# Altium schematic sheet STM Custom Shield for UAV.SchDoc("STM Custom Shield for UAV")
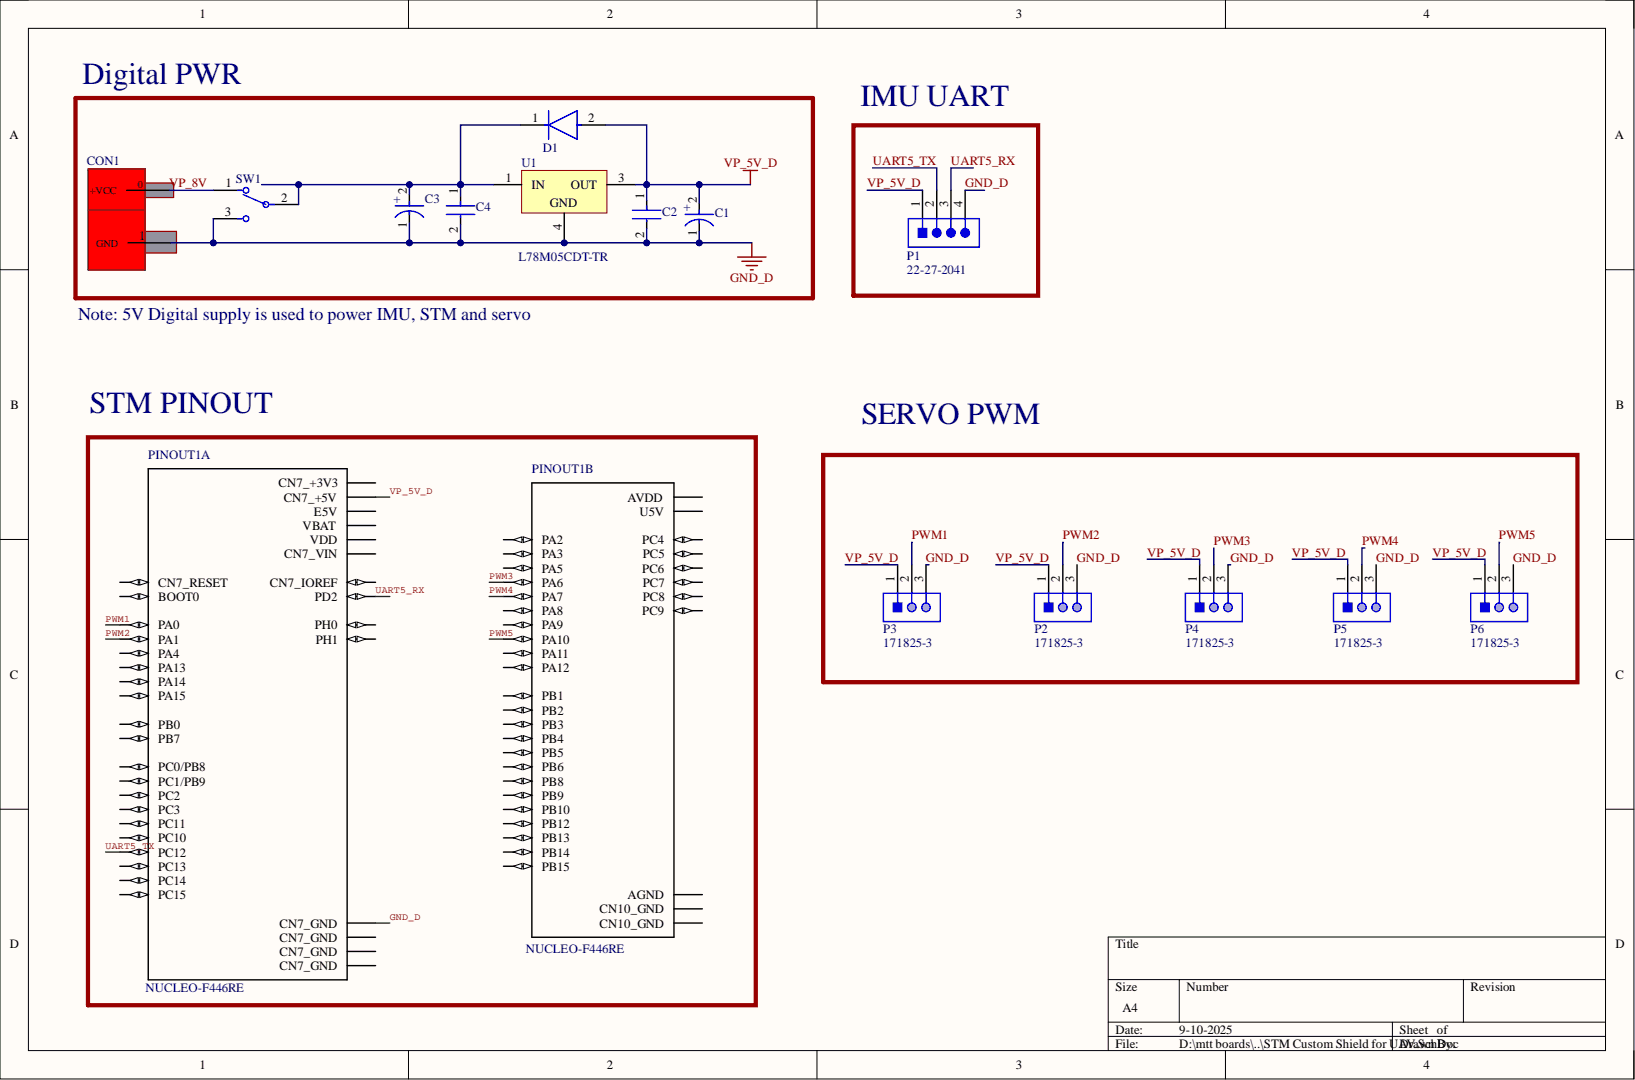
[diagram p0: STM Custom Shield for UAV.SchDoc]

## Parts
C1  EEUFR1H101  [FP-RAD-TH-D_8_0_5-L_11_5-MFG]  (value not drawn)
C2  12061C104JAT2A  [FP-1206-L_3_2_0_2-W_1_6_0-IPC_C]  (value not drawn)
C3  EEUFR1H101  [FP-RAD-TH-D_8_0_5-L_11_5-MFG]  (value not drawn)
C4  12061C104JAT2A  [FP-1206-L_3_2_0_2-W_1_6_0-IPC_C]  (value not drawn)
CON1  T CONN  [Edge Connector]  (value not drawn)
D1  STTH1L06U  [FP-SMB-MFG]  (value not drawn)
P1  22-27-2041  [MOLX-22-27-2041_V]
P2  171825-3  [TECO-171825-3_V]
P3  171825-3  [TECO-171825-3_V]
P4  171825-3  [TECO-171825-3_V]
P5  171825-3  [TECO-171825-3_V]
P6  171825-3  [TECO-171825-3_V]
PINOUT1  NUCLEO-F446RE  [*MODULE_NUCLEO-F446RE_NUCLEO-F446RE(Primary)]  (parts A,B)
SW1  A101SYCB04  [FP-A101SYCB04-MFG]  (value not drawn)
U1  L78M05CDT-TR  [TO-252_L]

## Nets
GND_D: C1.1 C2.2 C3.1 C4.2 CON1.1 P1.4 P2.3 P3.3 P4.3 P5.3 P6.3 PINOUT1.CN7_8(CN7_GND) SW1.3 U1.4
PWM1: P3.2 PINOUT1.CN7_28(PA0)
PWM2: P2.2 PINOUT1.CN7_30(PA1)
PWM3: P4.2 PINOUT1.CN10_13(PA6)
PWM4: P5.2 PINOUT1.CN10_15(PA7)
PWM5: P6.2 PINOUT1.CN10_33(PA10)
UART5_RX: P1.3 PINOUT1.CN7_4(PD2)
UART5_TX: P1.2 PINOUT1.CN7_3(PC12)
VP_5V_D: C1.2 C2.1 D1.2 P1.1 P2.1 P3.1 P4.1 P5.1 P6.1 PINOUT1.CN7_18(CN7_+5V) U1.3
VP_8V: CON1.0 SW1.1
NetC3_2: C3.2 C4.1 D1.1 SW1.2 U1.1

Not connected: PINOUT1 CN7_1(PC10) CN7_2(PC11) CN7_5(VDD) CN7_6(E5V) CN7_7(BOOT0) CN7_12(CN7_IOREF) CN7_13(PA13) CN7_14(CN7_RESET) CN7_15(PA14) CN7_16(CN7_+3V3) CN7_17(PA15) CN7_19(CN7_GND) CN7_20(CN7_GND) CN7_21(PB7) CN7_22(CN7_GND) CN7_23(PC13) CN7_24(CN7_VIN) CN7_25(PC14) CN7_27(PC15) CN7_29(PH0) CN7_31(PH1) CN7_32(PA4) CN7_33(VBAT) CN7_34(PB0) CN7_35(PC2) CN7_36(PC1/PB9) CN7_37(PC3) CN7_38(PC0/PB8) CN10_1(PC9) CN10_2(PC8) CN10_3(PB8) CN10_4(PC6) CN10_5(PB9) CN10_6(PC5) CN10_7(AVDD) CN10_8(U5V) CN10_9(CN10_GND) CN10_11(PA5) CN10_12(PA12) CN10_14(PA11) CN10_16(PB12) CN10_17(PB6) CN10_19(PC7) CN10_20(CN10_GND) CN10_21(PA9) CN10_22(PB2) CN10_23(PA8) CN10_24(PB1) CN10_25(PB10) CN10_26(PB15) CN10_27(PB4) CN10_28(PB14) CN10_29(PB5) CN10_30(PB13) CN10_31(PB3) CN10_32(AGND) CN10_34(PC4) CN10_35(PA2) CN10_37(PA3)

## Library data of the parts
C1 C3 = CMP-05427-002079-1: Aluminum Electrolytic Capacitors (Radial Lead Type) 100uF ±20% 50V; ESR (Equivalent Series Resistance): 61mR; Lead Diameter: 0.6mm; Lead/Base Style: Straight; Life (Hours): 6000Hour; Ripple Current: 870mA; Capacitance: 100uF; Case/Package: Radial; Component Kind: Standard; Construction: RADIAL; Contact Plating: Tin; Diameter: 8mm; Height: 11.5mm; Height - Seated (Max): 0.512inch; Impedance: 61mR; Lead Pitch: 3.5mm; Length: 11.5mm; Library Name: Altium Content Vault; Max Operating Temperature: 105°C; Min Operating Temperature: -40°C; Mount: Through Hole; Number of Pins: 2; Package Quantity: 200; Packaging: Bulk; Radiation Hardening: No; REACH SVHC: No SVHC; Ripple Current (AC): 870mA; RoHS Compliant: Yes; Series: FR; Termination: Radial; Tolerance: 20%; Voltage: 50V; Voltage Rating: 50V; Voltage Rating (DC): 50V
C2 C4 = CMP-04427-013576-1: General Purpose Ceramic Capacitor, 1206, 100nF, 5%, X7R, 15%, 100V; Capacitance: 100nF; Case Code (Imperial): 1206; Case Code (Metric): 3216; Case/Package: 1206; Component Kind: Standard; Construction: Flat; Contact Plating: Tin; Depth: 1.6mm; Dielectric: X7R; Dielectric Material: Ceramic; Height: 1.27mm; Lead Free: Lead Free; Length: 3.2mm; Library Name: Altium Content Vault; Max Operating Temperature: 125°C; Min Operating Temperature: -55°C; Mount: Surface Mount; Number of Pins: 2; Package Quantity: 1; Packaging: Tape and Reel; Radiation Hardening: No; REACH SVHC: No SVHC; RoHS Compliant: Yes; Series: -; Termination: SMD/SMT; Thickness: 0.05inch; Tolerance: 5%; Voltage: 100V; Voltage Rating: 100V; Voltage Rating (DC): 100V; Width: 0.063inch
D1 = CMP-12188-000011-1: DIODE GEN PURP 600V 1A SMB; Manufacturer: STMicroelectronics; Max Junction Temp: +175°C; Max Surge Forward Current: 20A; Peak Reverse Current: 1uA; Standoff Height: 0.05mm; Automotive: No; Category: Diode; Component Kind: Standard; ComponentLink2Description: Datasheet; ComponentLink2URL: https://www.st.com/resource/en/datasheet/stth1l06.pdf; Device Class L1: Discrete Semiconductors; Device Class L2: Diodes; Device Class L3: Rectifier Diodes; Digikey Description: DIODE GEN PURP 600V 1A SMB; Forward Current: 1A; Height: 2.65mm; Lead Free: Yes; Library Name: Altium Content Vault; Manufacturer Part Number: STTH1L06U; Max Repetitive Reverse Voltage: 600V; Mouser Description: STTH1L06 Series 1 A 1.05 V 80 ns Turbo 2 Ultrafast Rectifier SMT - DO-214AA; Octopart: https://octopart.com/stth1l06u-stmicroelectronics-324444; Package: SMB; Reverse Voltage: 600V; RoHS: TRUE; Temperature: to +175°C; Temperature Range High: +175°C
P1 = CMP-2000-05243-1: Male Header, Pitch 2.54 mm, 1 x 4 Position, Height 11.7 mm, Tail Length 3.56 mm, RoHS, Bulk; Manufacturer: Molex; Current rating: 4A; Voltage rating: 250V; Component Kind: Standard; Contact material: Tinplatedbrass; Datasheet URL: http://www.molex.com/pdm_docs/sd/022272041_sd.pdf; Datasheet Version: Rev. F, 06/2009; Housing material: Nylon(UL94V-0); Library Name: Altium Content Vault; Manufacturer URL: http://www.molex.com/; Operating temperature: 0degCto+75degC
P2-P6 = CMP-2000-07494-1: Male Header, Pitch 2.5 mm, 1 x 3 Position, Height 10.4 mm, Tail Length 3.6 mm,   -20 to 95 degC  , RoHS, Bulk; Manufacturer: TE Connectivity; Approvals: UL; Backwall/Post Interruptions: No(Without); Contact Resistance: 20mOhm; Mating Alignment: Yes(With); Mating Post Length: 7.5mm; Max Current Rating: 3.5A; Max Voltage Rating (AC): 250V; Max Voltage Rating (DC): 350.25kV; Number of Contacts: 3; PCB Mount Retention: Without; PCB Mounting Orientation: Vertical; PCB Thickness: 0.063inch; Pitch: 2.5mm; Plating Thickness: 0.8um; Tail Length: 0.142inch; Voltage Rating: 350V; Colour: Natural; Component Kind: Standard; Connector Type: Header; Contact Gender: Male; Contact Material: Brass; Contact Mating Length: 0.295inch; Contact Plating: Tin; Contact Retention: No(Without); Contact Shape: Square; Datasheet URL: http://www.te.com/commerce/DocumentDelivery/DDEController?Action=showdoc&DocId=Customer+Drawing%7F171825%7FY3%7Fpdf%7FEnglish%7FENG_CD_171825_Y3.pdf%7F171825-3; Datasheet Version: Rev. Y3, 12/2016; Depth: 4.9mm; Flammability Rating: UL94V-0; Height: 0.409inch; Housing Colour: Natural; Housing Material: Nylon; Length: 7.5mm; Library Name: Altium Content Vault; Manufacturer URL: http://www.te.com/; Mating Retention: Yes(With); Max Operating Temperature: 95degC; Min Operating Temperature: -20degC; Mount: ThroughHole; Mounting Technology: ThroughHole; Number of Positions: 3; Number of Rows: 1; Orientation: Straight; Package Description: Male Header, Pitch 2.5 mm, 1 x 3 Position, Height 10.4 mm, Tail Length 3.6 mm; Package Quantity: 1000; Package Reference: 171825-3; Packaging: Bag; Panel Mount Retention: No(Without); PCB Mount Alignment: No(Without); REACH SVHC: NoSVHC; RoHSCompliant: True; Strain Relief: No(Without); Termination: Solder
SW1 = CMP-03408-000017-1: SWITCH TOGGLE SPDT 0.4VA 20V; Actuator Color: Chrome; Actuator Length: 10.41mm; Actuator Material: Phenolic; Actuator Type: Standard Round; Contact Current Rating: 400mA; Contact Resistance: 10mR; Electrical Life: 6000Cycles; Illumination Type: Non-Illuminated; Insulation Resistance: 30MR; Number of Poles: 1; Number of Positions: 2; Power Rating: 400mW; Switch Function: On-On; Component Kind: Standard; Contact Material: Copper; Contact Plating: Gold; Depth: 6.86mm; ELV: Compliant; Flammability Rating: UL94 V-1; Lead Free: Lead Free; Library Name: Altium Content Vault; Max Operating Temperature: 85°C; Max Voltage Rating (AC): 20V; Max Voltage Rating (DC): 20V; Min Operating Temperature: -30°C; Mount: Panel; Orientation: Straight; Package Quantity: 100; Packaging: Bulk; Radiation Hardening: No; REACH SVHC: No SVHC; RoHS Compliant: Yes; Series: Gemini A; Termination: PC Pins; Throw Configuration: SPDT; Voltage Rating (AC): 20V; Voltage Rating (DC): 20V
U1 = CMP-0244-00178-1: Positive Voltage Regulator, 5V, 3-Pin DPAK, Tape and Reel; Component Kind: Standard; ComponentLink1Description: Manufacturer URL; ComponentLink1URL: http://www.st.com; ComponentLink2Description: Datasheet; ComponentLink2URL: http://www.st.com/internet/com/TECHNICAL_RESOURCES/TECHNICAL_LITERATURE/DATASHEET/CD00000447.pdf; DatasheetVersion: 1/11/2010; Library Name: Altium Content Vault; PackageDescription: 3-Pin Transistor Outline Package 2.28 mm Pitch, 9.7 mm Lead Span (DPAK); PackageReference: TO-252; PackageVersion: 2011
CON1 = T CONN: Component Kind: Standard; Library Name: t conn.IntLib
PINOUT1 = NUCLEO-F446RE: Check_prices: https://www.snapeda.com/parts/NUCLEO-F446RE/STMicroelectronics/view-part/?ref=eda; Component Kind: Standard; Library Name: NUCLEO-F446RE.IntLib; SnapEDA_Link: https://www.snapeda.com/parts/NUCLEO-F446RE/STMicroelectronics/view-part/?ref=snap
